# Altium schematic sheet LIDO-HW.300.VehicleInterface.SchDoc(LIDO-HW.300.VehicleInterface)
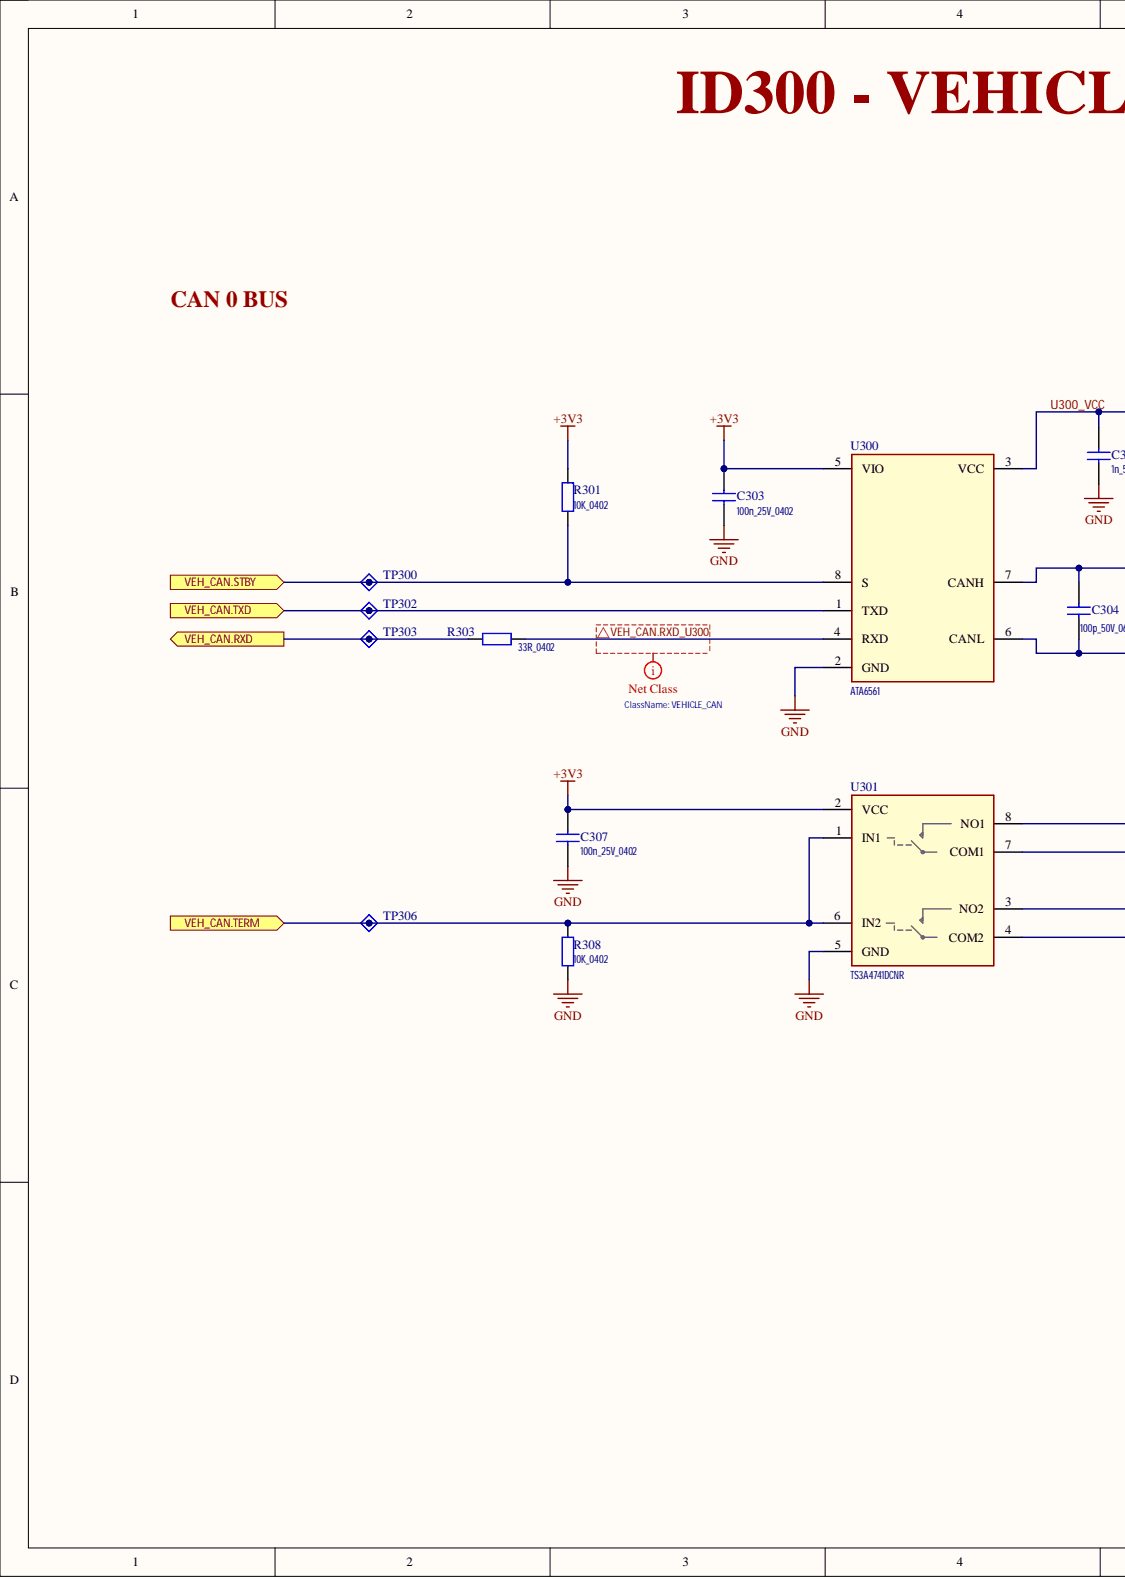
[diagram p0: LIDO-HW.300.VehicleInterface.SchDoc, left]
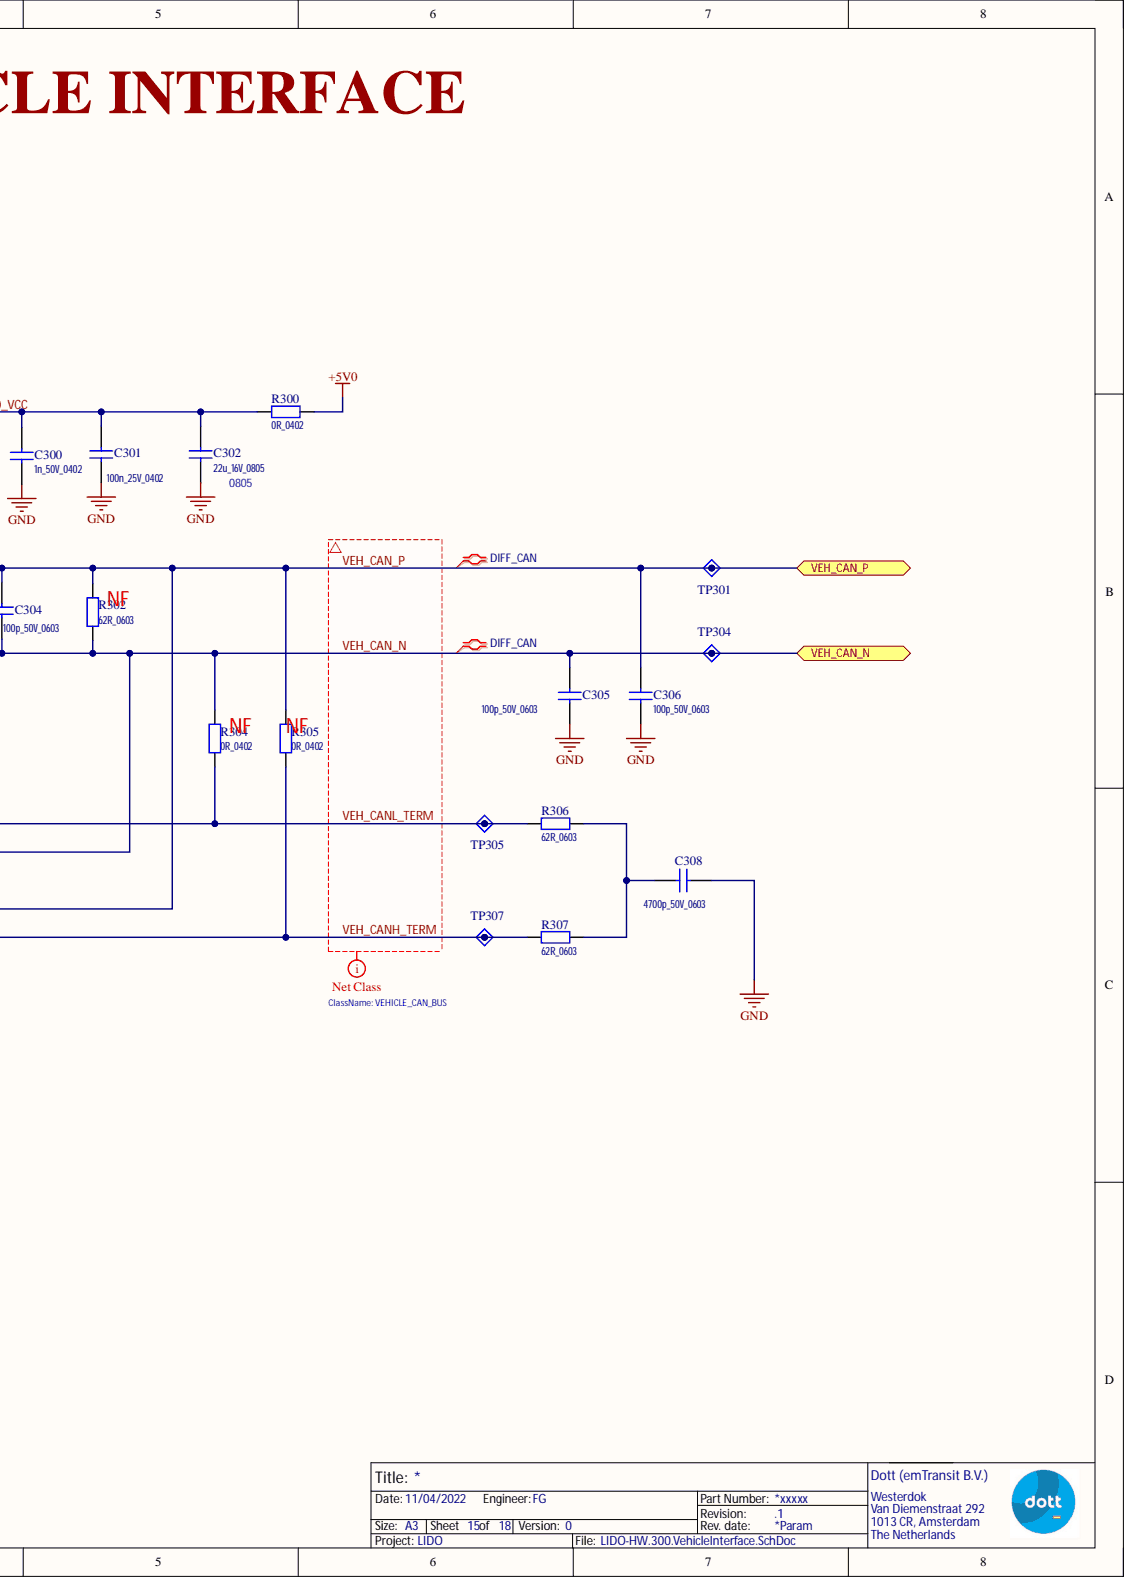
[diagram p1: LIDO-HW.300.VehicleInterface.SchDoc, right]

## Parts
C300  1n_50V_0402
C301  100n_25V_0402
C302  22u_16V_0805
C303  100n_25V_0402
C304  100p_50V_0603
C305  100p_50V_0603
C306  100p_50V_0603
C307  100n_25V_0402
C308  4700p_50V_0603
R300  0R_0402
R301  10K_0402
R302  62R_0603
R303  33R_0402
R304  0R_0402
R305  0R_0402
R306  62R_0603
R307  62R_0603
R308  10K_0402
TP300  TestPoint_PWR  (value not drawn)  (no BOM)
TP301  TestPoint_PWR  (value not drawn)  (no BOM)
TP302  TestPoint_PWR  (value not drawn)  (no BOM)
TP303  TestPoint_PWR  (value not drawn)  (no BOM)
TP304  TestPoint_PWR  (value not drawn)  (no BOM)
TP305  TestPoint_PWR  (value not drawn)  (no BOM)
TP306  TestPoint_PWR  (value not drawn)  (no BOM)
TP307  TestPoint_PWR  (value not drawn)  (no BOM)
U300  ATA6561  [SOIC8P127_490X600X175L83X42N]
U301  TS3A4741DCNR  [TSOP65P280X145-8N]

## Ports
VEH_CAN.RXD, VEH_CAN.STBY, VEH_CAN.TERM, VEH_CAN.TXD, VEH_CAN_N, VEH_CAN_P

## Nets
+3V3: C303.1 C307.1 R301.1 U300.5(VIO) U301.2(VCC)
+5V0: R300.1
GND: C300.2 C301.2 C302.2 C303.2 C305.2 C306.1 C307.2 C308.2 R308.2 U300.2(GND) U301.5(GND)
U300_VCC: C300.1 C301.1 C302.1 R300.2 U300.3(VCC)
VEH_CAN.RXD: R303.2 TP303.1 ; port VEH_CAN.RXD
VEH_CAN.RXD_U300: R303.1 U300.4(RXD)
VEH_CAN.STBY: R301.2 TP300.1 U300.8(S) ; port VEH_CAN.STBY
VEH_CAN.TERM: R308.1 TP306.1 U301.1(IN1) U301.6(IN2) ; port VEH_CAN.TERM
VEH_CAN.TXD: TP302.1 U300.1(TXD) ; port VEH_CAN.TXD
VEH_CAN_N: C304.2 C305.1 R302.2 R304.1 TP304.1 U300.6(CANL) U301.7(COM1) ; port VEH_CAN_N
VEH_CAN_P: C304.1 C306.2 R302.1 R305.1 TP301.1 U300.7(CANH) U301.3(NO2) ; port VEH_CAN_P
VEH_CANH_TERM: R305.2 R307.2 TP307.1 U301.4(COM2)
VEH_CANL_TERM: R304.2 R306.2 TP305.1 U301.8(NO1)
NetC308_1: C308.1 R306.1 R307.1

## Library data of the parts
C300-C308 = Ceramic: Ceramic CAP Symbol; Component Kind: Standard; Library Name: 01_Capacitors.SchLib
R300-R308 = Resistor: Resistor Symbol; Component Kind: Standard; Library Name: 02_Resistors.SchLib
TP300-TP307 = TestPoint_A: Test Point Symbol verion A; 00_Name: TP_XXmm; 01_Diameter: 1mm; Library Name: 31_MFG_Elements.SchLib
U300 = ATA6561_A: IC TRANSCEIVER 1/1 8SO; Manufacturer: Microchip Technology; Manufacturer Part Number: ATA6561-GAQW; Designer: Matt Drazenovich; Ratings: RoHS; Reviewed: Dekimo_07-07-2020; Case/Package: 8-SOIC; Component Kind: Standard; ComponentType: IC; Design Date: 22-11-2019; Library Name: 15_IC_Interfaces.SchLib; Max Operating Temperature: 150°C; Min Operating Temperature: -40°C; Value: ATA6561
U301 = TS3A4741D_A: 2 Circuit IC Switch 1:1 900mOhm SOT-23-8; Manufacturer: Texas Instruments; Designer: Matt Drazenovich; Ratings: RoHS; Reviewed: Not Footprint; Case/Package: SOT-23-8; Component Kind: Standard; ComponentType: IC; Design Date: 09-09-2021; Library Name: 15_IC_Interfaces.SchLib; Manufacturer Part Number: TS3A4741DCNR; Max Operating Temperature: 85°C; Min Operating Temperature: -40°C; Value: TS3A4741DCNR
